# Altium schematic sheet MISC.SchDoc(MISC)
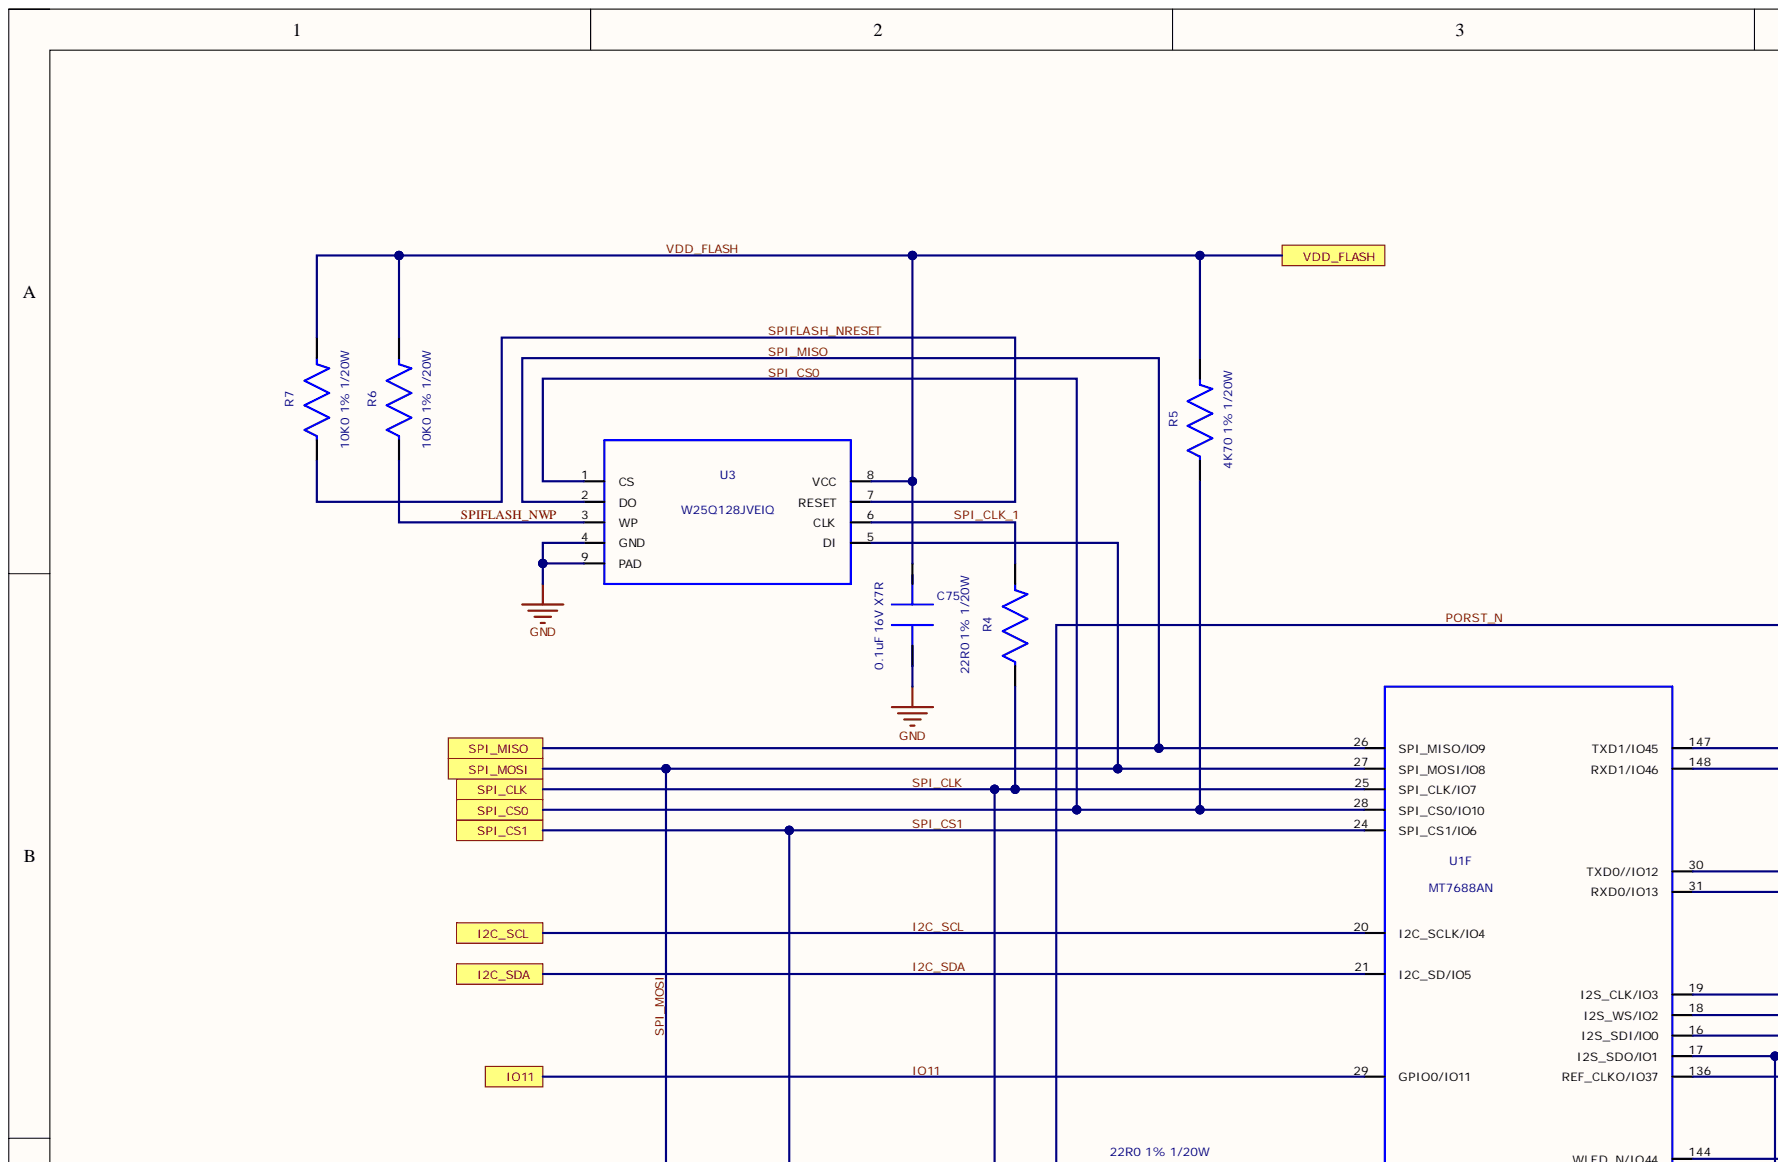
[diagram p0: MISC.SchDoc, top left]
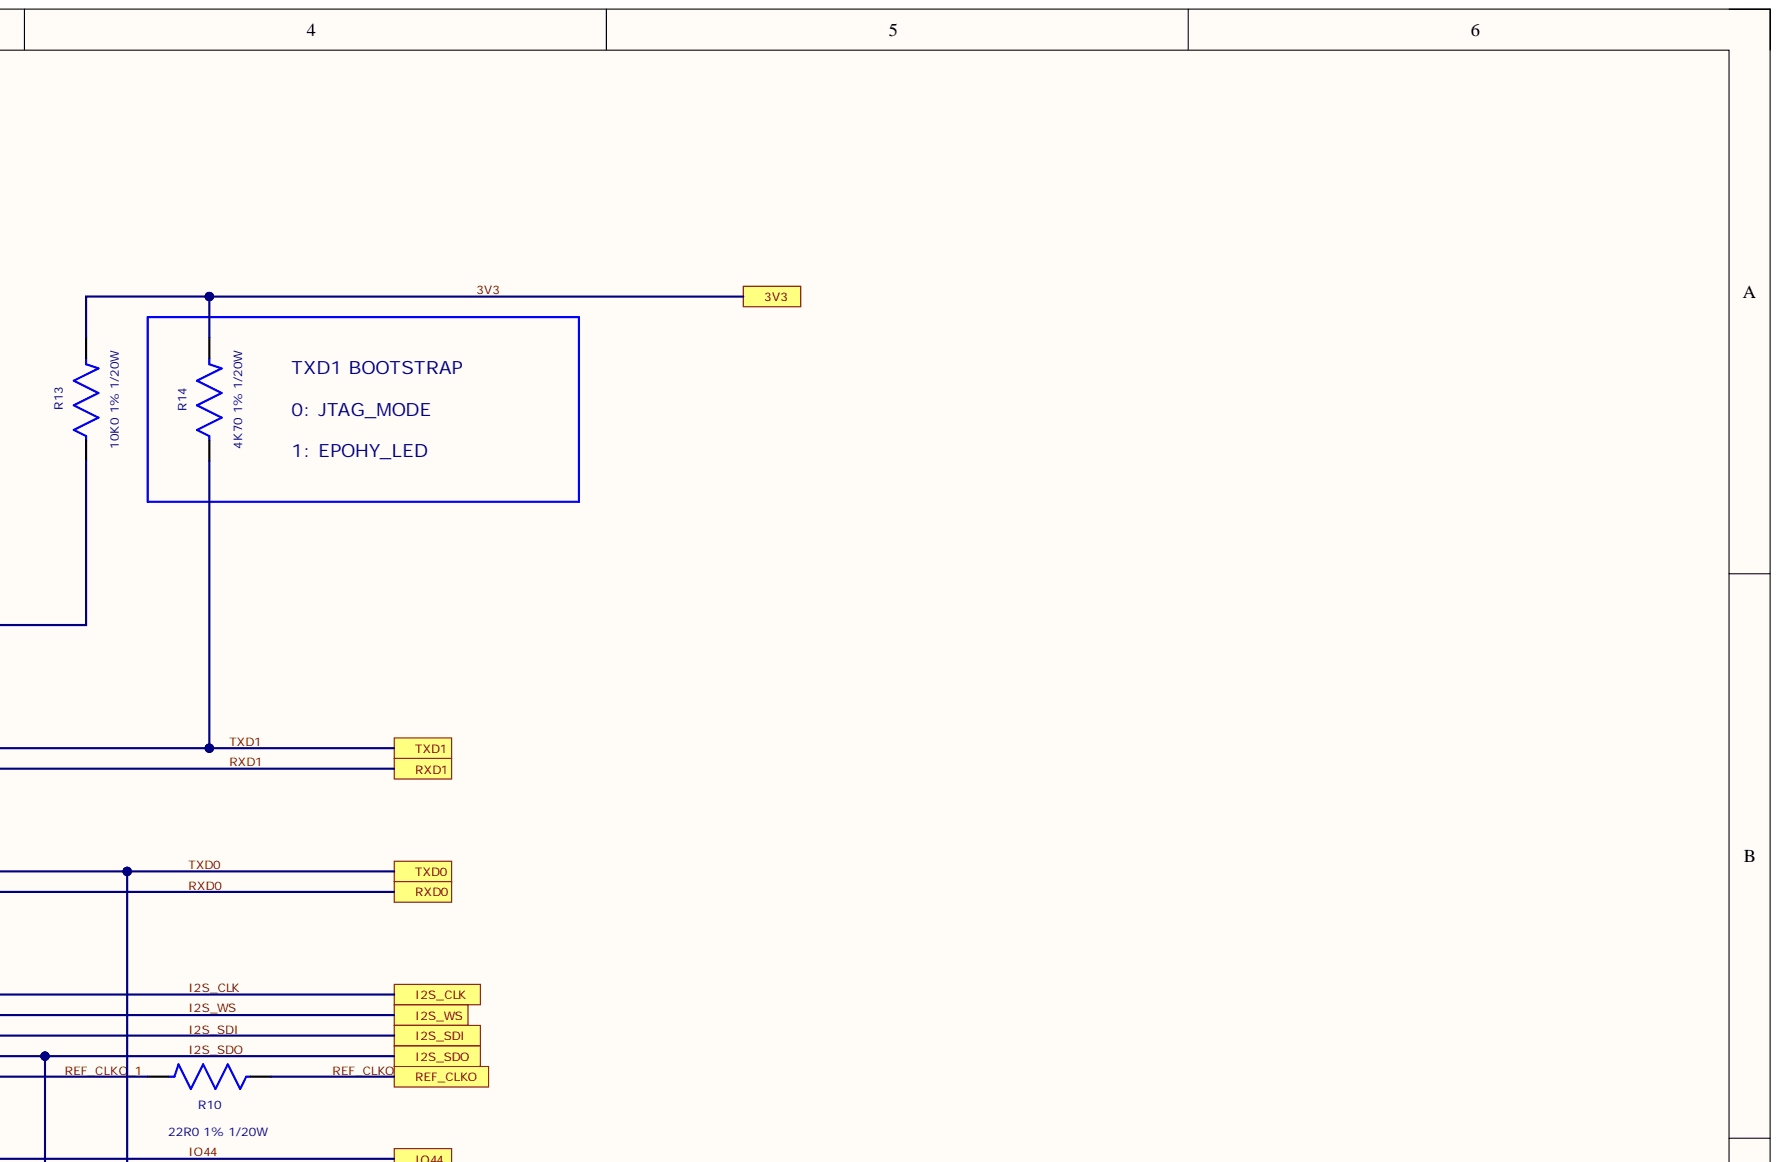
[diagram p1: MISC.SchDoc, top right]
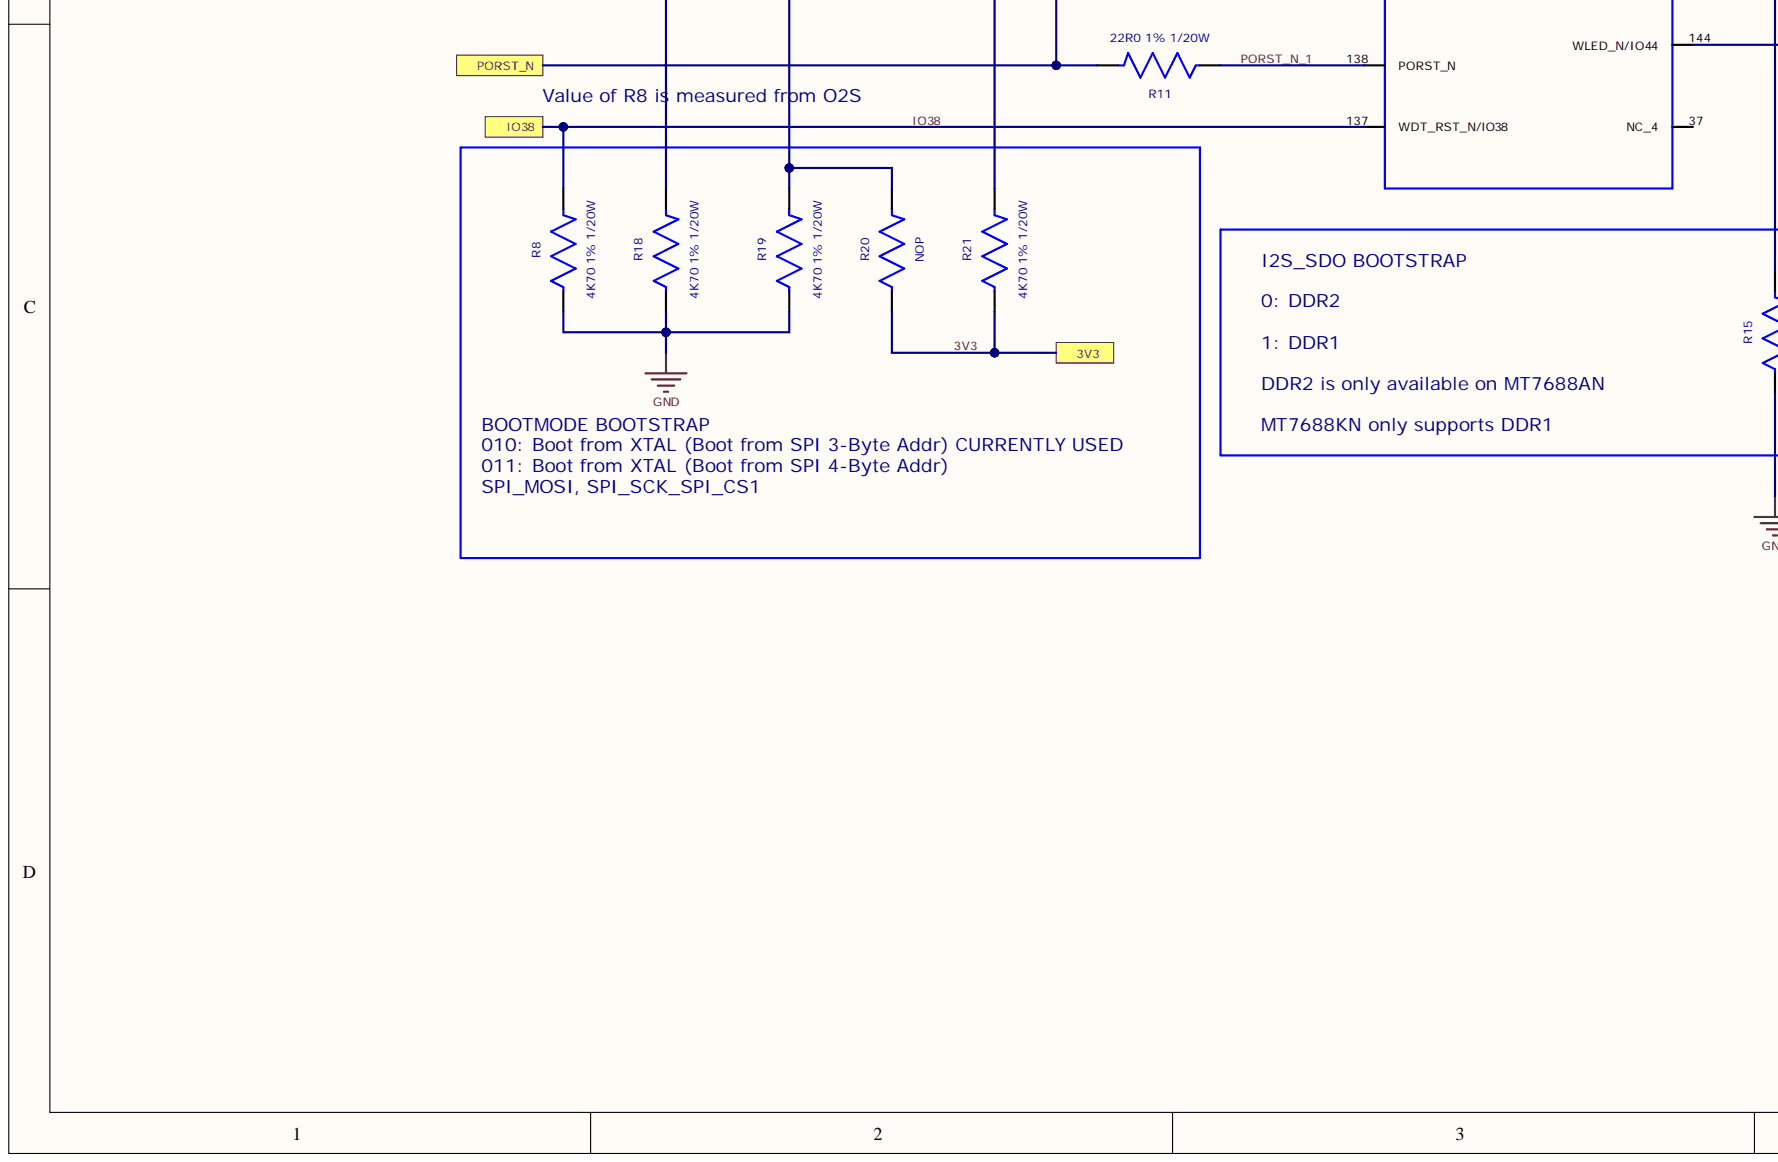
[diagram p2: MISC.SchDoc, bottom left]
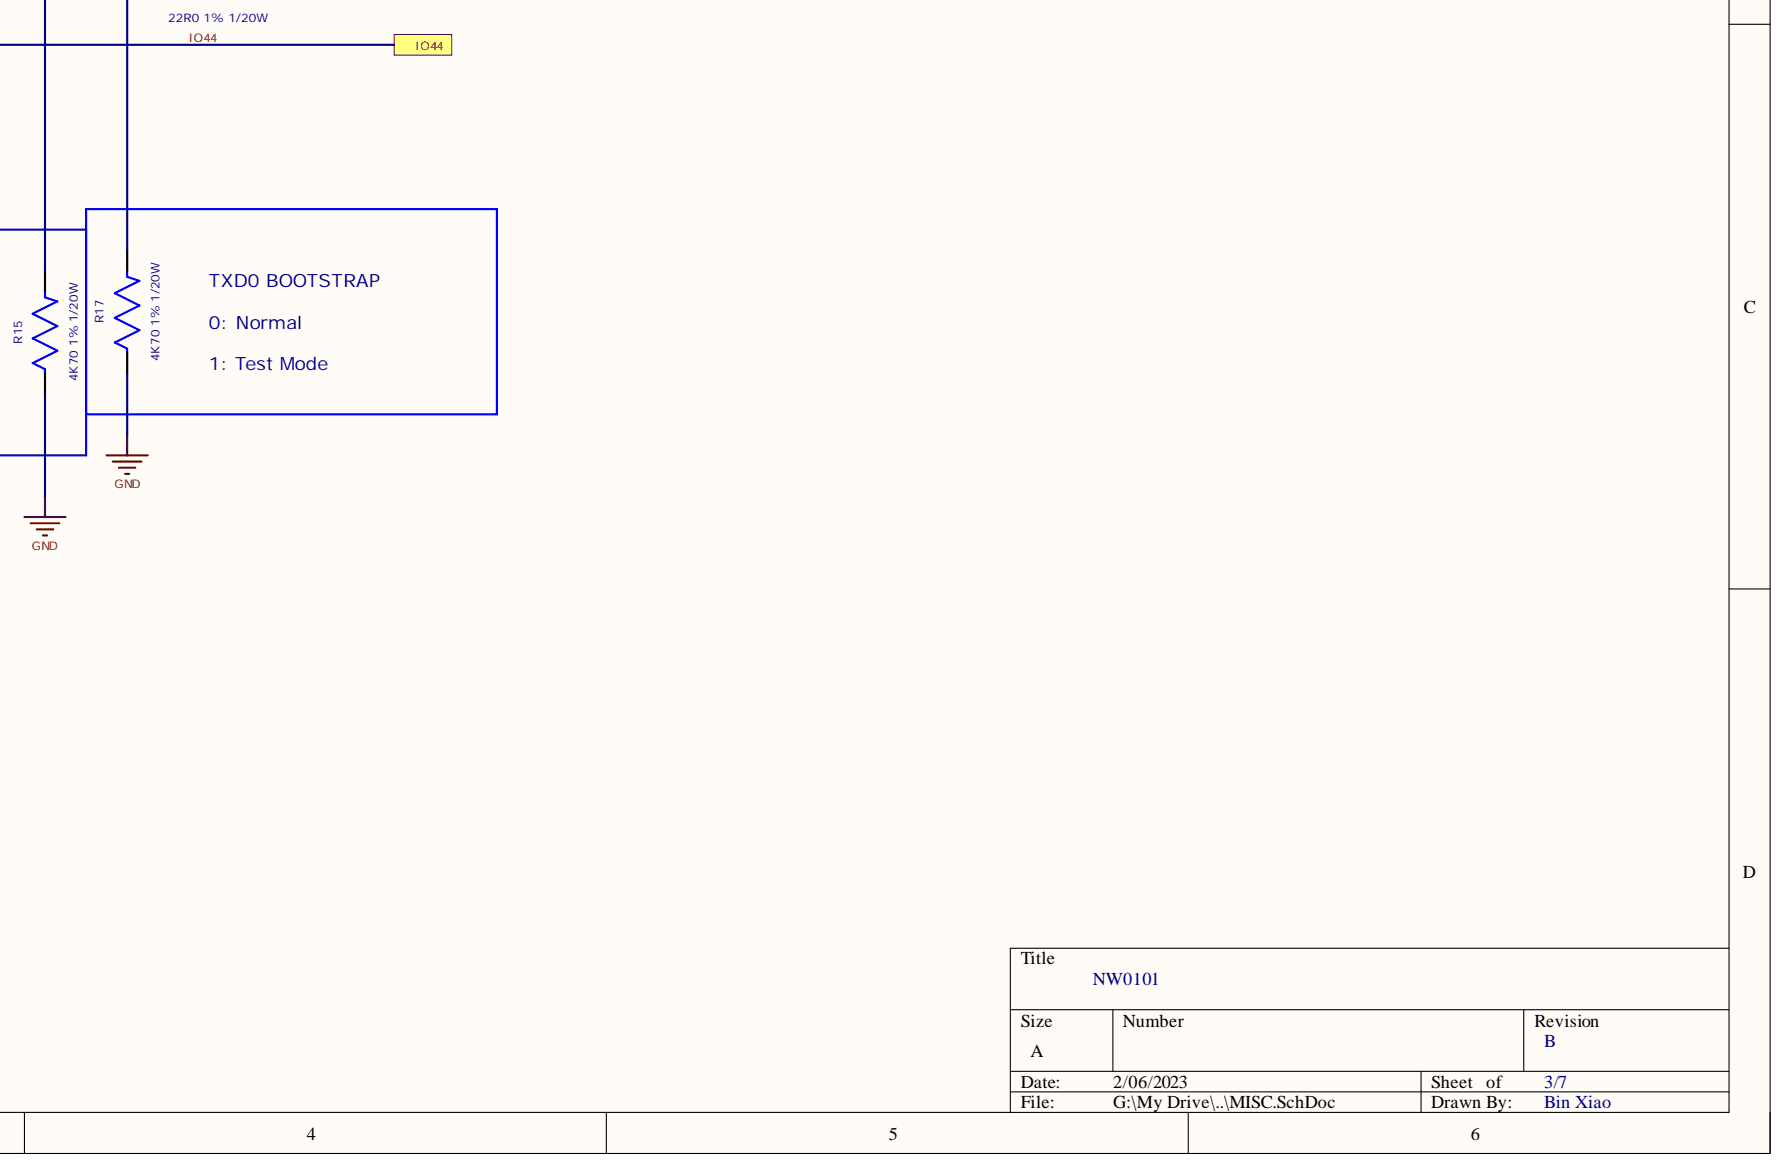
[diagram p3: MISC.SchDoc, bottom right]

## Parts
C75  value 0.1uF 16V X7R  [C0201]  (hidden comment: CAP0201-104-16V-X7R)
R4  value 22R0 1% 1/20W  [R0201]  (hidden comment: RES0201-22R0)
R5  value 4K70 1% 1/20W  [R0201]  (hidden comment: RES0201-4K70)
R6  value 10K0 1% 1/20W  [R0201]  (hidden comment: RES0201-10K0)
R7  value 10K0 1% 1/20W  [R0201]  (hidden comment: RES0201-10K0)
R8  value 4K70 1% 1/20W  [R0201]  (hidden comment: RES0201-4K70)
R10  value 22R0 1% 1/20W  [R0201]  (hidden comment: RES0201-22R0)
R11  value 22R0 1% 1/20W  [R0201]  (hidden comment: RES0201-22R0)
R13  value 10K0 1% 1/20W  [R0201]  (hidden comment: RES0201-10K0)
R14  value 4K70 1% 1/20W  [R0201]  (hidden comment: RES0201-4K70)
R15  value 4K70 1% 1/20W  [R0201]  (hidden comment: RES0201-4K70)
R17  value 4K70 1% 1/20W  [R0201]  (hidden comment: RES0201-4K70)
R18  value 4K70 1% 1/20W  [R0201]  (hidden comment: RES0201-4K70)
R19  value 4K70 1% 1/20W  [R0201]  (hidden comment: RES0201-4K70)
R20  value NOP  [R0201_NOP]  (hidden comment: RES0201-NOP)
R21  value 4K70 1% 1/20W  [R0201]  (hidden comment: RES0201-4K70)
U1  MT7688AN  [DR-QFN-128]  (part F)
U3  W25Q128JVEIQ  [WIN_E-8_WIN]

## Ports
3V3, I2C_SCL, I2C_SDA, I2S_CLK, I2S_SDI, I2S_SDO, I2S_WS, IO11, IO38, IO44, PORST_N, REF_CLKO, RXD0, RXD1, SPI_CLK, SPI_CS0, SPI_CS1, SPI_MISO, SPI_MOSI, TXD0, TXD1, VDD_FLASH

## Nets
GND: C75.2 R8.1 R15.1 R17.1 R18.1 R19.1 U3.4(GND) U3.9(PAD)
3V3: R13.2 R14.2 R20.1 R21.1 ; port 3V3
I2C_SCL: U1.20(I2C_SCLK/IO4) ; port I2C_SCL
I2C_SDA: U1.21(I2C_SD/IO5) ; port I2C_SDA
I2S_CLK: U1.19(I2S_CLK/IO3) ; port I2S_CLK
I2S_SDI: U1.16(I2S_SDI/IO0) ; port I2S_SDI
I2S_SDO: R15.2 U1.17(I2S_SDO/IO1) ; port I2S_SDO
I2S_WS: U1.18(I2S_WS/IO2) ; port I2S_WS
IO11: U1.29(GPIO0/IO11) ; port IO11
IO38: R8.2 U1.137(WDT_RST_N/IO38) ; port IO38
IO44: U1.144(WLED_N/IO44) ; port IO44
PORST_N: R11.2 R13.1 ; port PORST_N
PORST_N_1: R11.1 U1.138(PORST_N)
REF_CLKO: R10.1 ; port REF_CLKO
REF_CLKO_1: R10.2 U1.136(REF_CLKO/IO37)
RXD0: U1.31(RXD0/IO13) ; port RXD0
RXD1: U1.148(RXD1/IO46) ; port RXD1
SPI_CLK: R4.1 R21.2 U1.25(SPI_CLK/IO7) ; port SPI_CLK
SPI_CLK_1: R4.2 U3.6(CLK)
SPI_CS0: R5.1 U1.28(SPI_CS0/IO10) U3.1(CS) ; port SPI_CS0
SPI_CS1: R19.2 R20.2 U1.24(SPI_CS1/IO6) ; port SPI_CS1
SPI_MISO: U1.26(SPI_MISO/IO9) U3.2(DO) ; port SPI_MISO
SPI_MOSI: R18.2 U1.27(SPI_MOSI/IO8) U3.5(DI) ; port SPI_MOSI
SPIFLASH_NRESET: R7.1 U3.7(RESET)
SPIFLASH_NWP: R6.1 U3.3(WP)
TXD0: R17.2 U1.30(TXD0//IO12) ; port TXD0
TXD1: R14.1 U1.147(TXD1/IO45) ; port TXD1
VDD_FLASH: C75.1 R5.2 R6.2 R7.2 U3.8(VCC) ; port VDD_FLASH

Not connected: U1 37(NC_4)

## Library data of the parts
C75 = CAP0201-104-16V-X7R: CAP CER 0.1UF 16V X7R 0201; Manufacturer: Murata Electronics; Part Number: GRM033Z71C104KE14D; Aligni Value: 0.1u; Geometry.Height: 0.33mm; PCB DECAL: RC0201; Prefered Vender: Digikey; Type: Capacitor; Component Kind: Standard; Revision: A; Library Name: NetworkModule.SCHLIB
R4 R10 R11 = RES0201-22R0: RES 22 OHM 1% 1/20W 0201; Manufacturer: Yageo; Part Number: RC0201FR-0722RL; Aligni Value: 22; Geometry.Height: 0.5mm; PCB DECAL: RC0201; Prefered Vender: Digikey; Component Kind: Standard; Revision: A; Type: Resistor; Library Name: NetworkModule.SCHLIB
R5 R8 R14 R15 R17-R19 R21 = RES0201-4K70: RES 4.7K OHM 1% 1/20W 0201; Manufacturer: Yageo; Part Number: RC0201FR-074K7L; Geometry.Height: 0.5mm; PCB DECAL: RC0201; Prefered Vender: Digikey; Aligni Value: 4.7k; Component Kind: Standard; Revision: A; Type: Resistor
  R5 R8 R14 R15 R17 R18 R21: Library Name: NetworkModule.SCHLIB
  R19: Library Name: NetworkModule.IntLib
R6 R7 R13 = RES0201-10K0: RES SMD 10K OHM 1% 1/20W 0201; Manufacturer: Yageo; Part Number: RC0201FR-0710KP; Geometry.Height: 0.5mm; PCB DECAL: RC0201; Prefered Vender: Digikey; Aligni Value: 10k; Component Kind: Standard; Revision: A; Type: Resistor; Library Name: NetworkModule.SCHLIB
R20 = RES0201-NOP: NOP; Geometry.Height: 0mm; PCB DECAL: RC0201; Aligni Value: NOP; Component Kind: Standard; Manufacturer: NOP; Part Number: NOP; Prefered Vender: NOP; Revision: A; Type: Resistor; Library Name: NetworkModule.SCHLIB
U1 = MT7688AN: 580Mhz 32bits Networking Processor; Manufacturer: MediaTek Inc; Geometry.Height: 0mm; Component Kind: Standard; Part Number: MT7688AN; PCB DECAL: DR-QFN-128; Type: IC
U3 = W25Q128JVEIQ: IC FLASH 128MBIT SPI/QUAD 8WSON; Manufacturer: Winbond Electronics; Prefered Vender: Digikey; Component Kind: Standard; Part Number: W25Q128JVEIQ; PCB DECAL: WIN_E-8_WIN; Type: IC; Library Name: NetworkModule.SCHLIB
